# Altium schematic sheet 14-RegenSolenoid.SchDoc(14-RegenSolenoid)
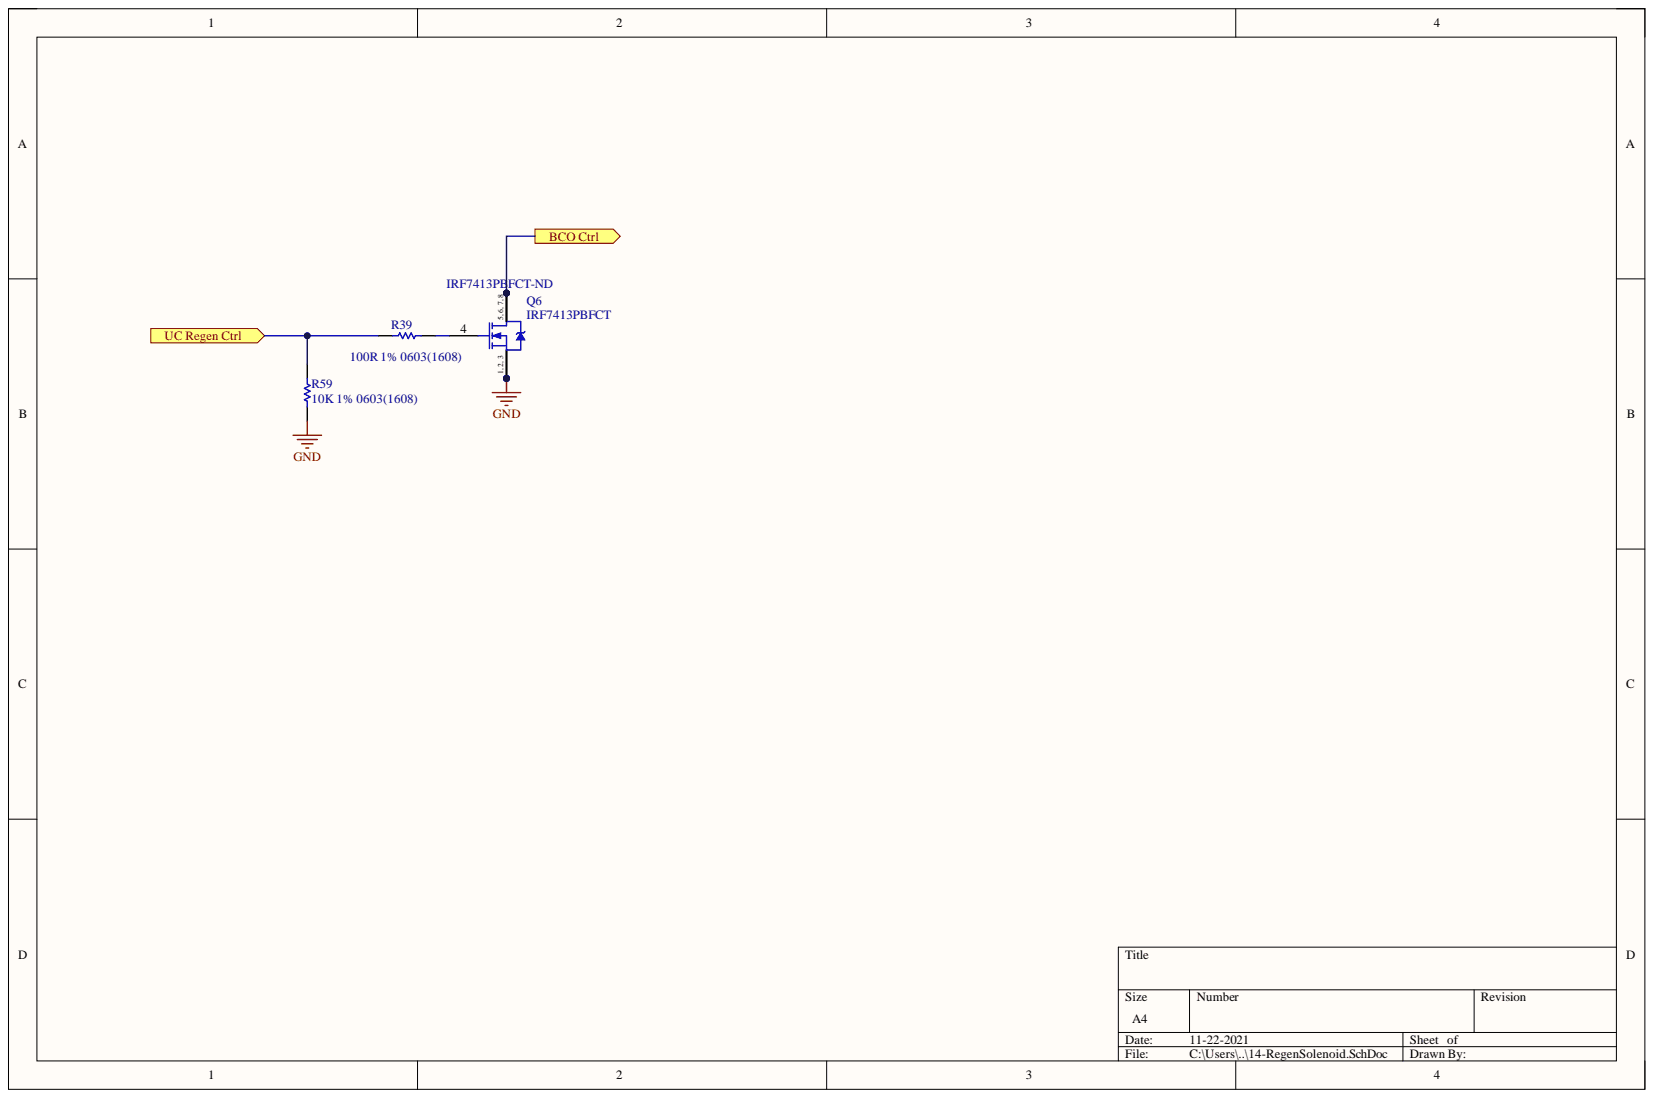
[diagram p0: 14-RegenSolenoid.SchDoc]

## Parts
Q6  IRF7413PBFCT  Supplier Part Number 1: IRF7413PBFCT-ND  [INTR-SO-8_V]
R39  100R 1% 0603(1608)  [RESC0603(1608)_N]
R59  10K 1% 0603(1608)  [RESC0603(1608)_N]

## Ports
BCO Ctrl, UC Regen Ctrl

## Nets
GND: Q6.1 Q6.2 Q6.3 R59.1
BCO Ctrl: Q6.5 Q6.6 Q6.7 Q6.8 ; port BCO Ctrl
UC Regen Ctrl: R39.2 R59.2 ; port UC Regen Ctrl
NetQ6_4: Q6.4 R39.1

## Library data of the parts
Q6 = CMP-2000-06466-1: HEXFET (R) Power MOSFET, 30 V, -55 to 150 degC, 8-Pin SO, RoHS, Tube; Manufacturer: International Rectifier; Channel Type: N-Channel; Drain-Source On Resistance-Max: 0.011O; Drain-to-Source Voltage [Vdss]: 30V; Qg Gate Charge: 52nC; Rated Power Dissipation: 2.5W; Component Kind: Standard; Datasheet URL: http://www.infineon.com/dgdl/irf7413pbf.pdf?fileId=5546d462533600a4015355fa8e901bb8; Datasheet Version: 02/2008; Library Name: Altium Content Vault; Manufacturer URL: http://internationalrectifier.com/; Mounting Technology: SurfaceMount; Package Description: 8-Pin Small Outline Integrated Circuit, Body 4.9 x 3.9 mm, Pitch 1.27 mm; Package Reference: SO-8; Supplier 1: Digi-Key
R39 = CMP-1012-00397-1: 100R 0.1W 1% 0603 (1608 Metric)  SMD; Case-EIA: 0603; Case-Metric: 1608; Value: 100.00 Ohm; Component Kind: Standard; Library Name: Altium Content Vault; Power: 0.1 W; Technology: SMT; Tolerance: 1 %
R59 = CMP-1012-00623-1: 10K 0.1W 1% 0603 (1608 Metric)  SMD; Case-EIA: 0603; Case-Metric: 1608; Value: 10000.00 Ohm; Component Kind: Standard; Library Name: Altium Content Vault; Power: 0.1 W; Technology: SMT; Tolerance: 1 %
